# Altium schematic sheet 01mcu.SchDoc
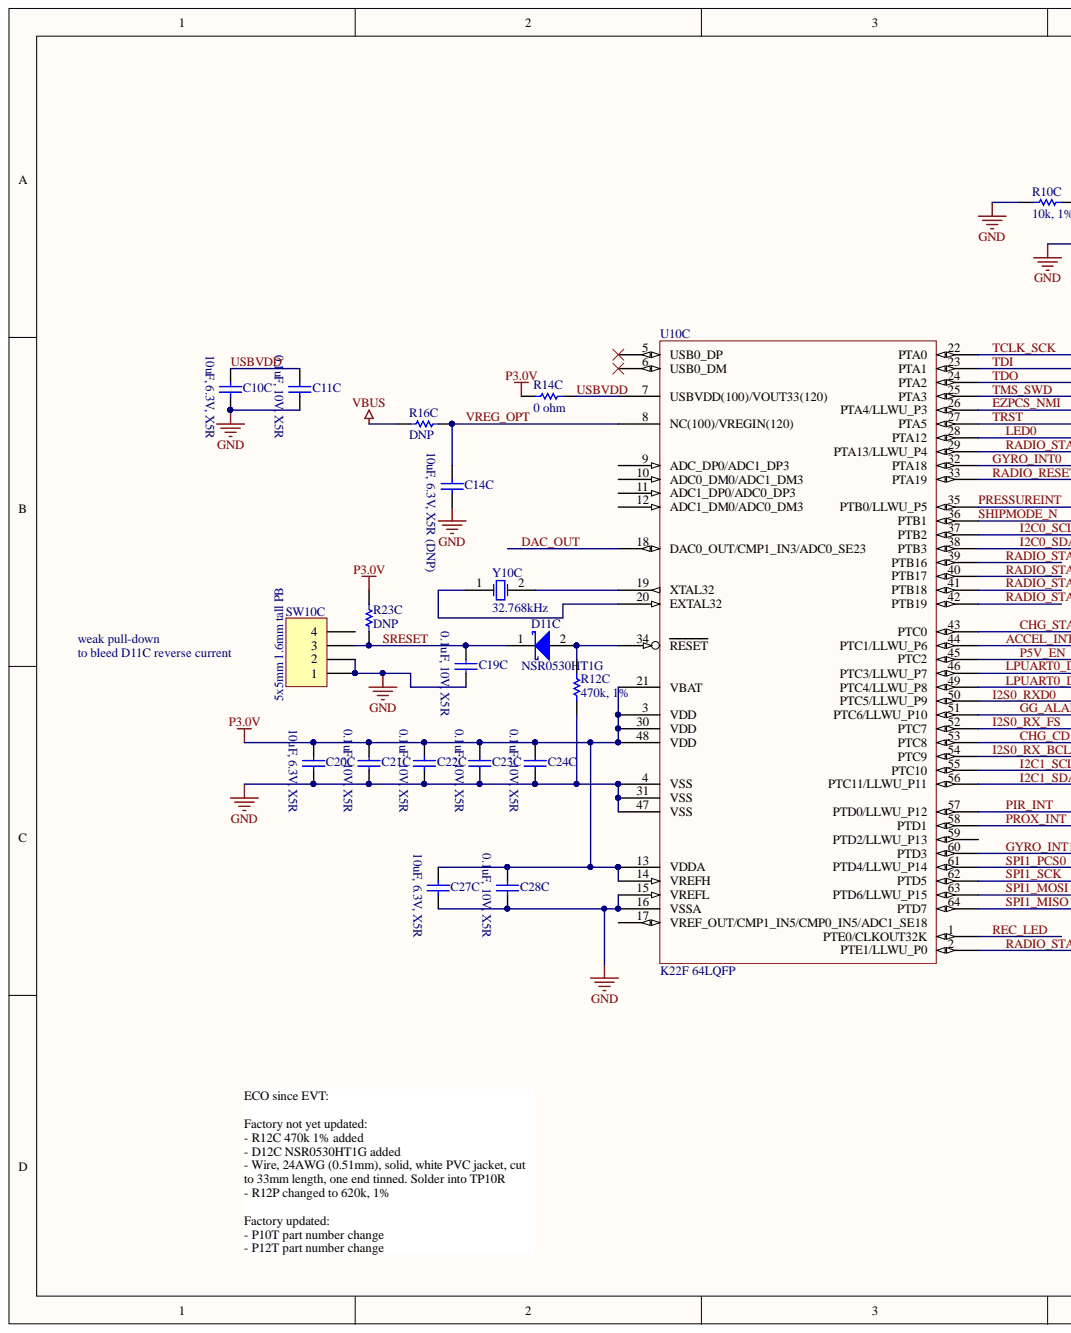
[diagram p0: 01mcu.SchDoc, left]
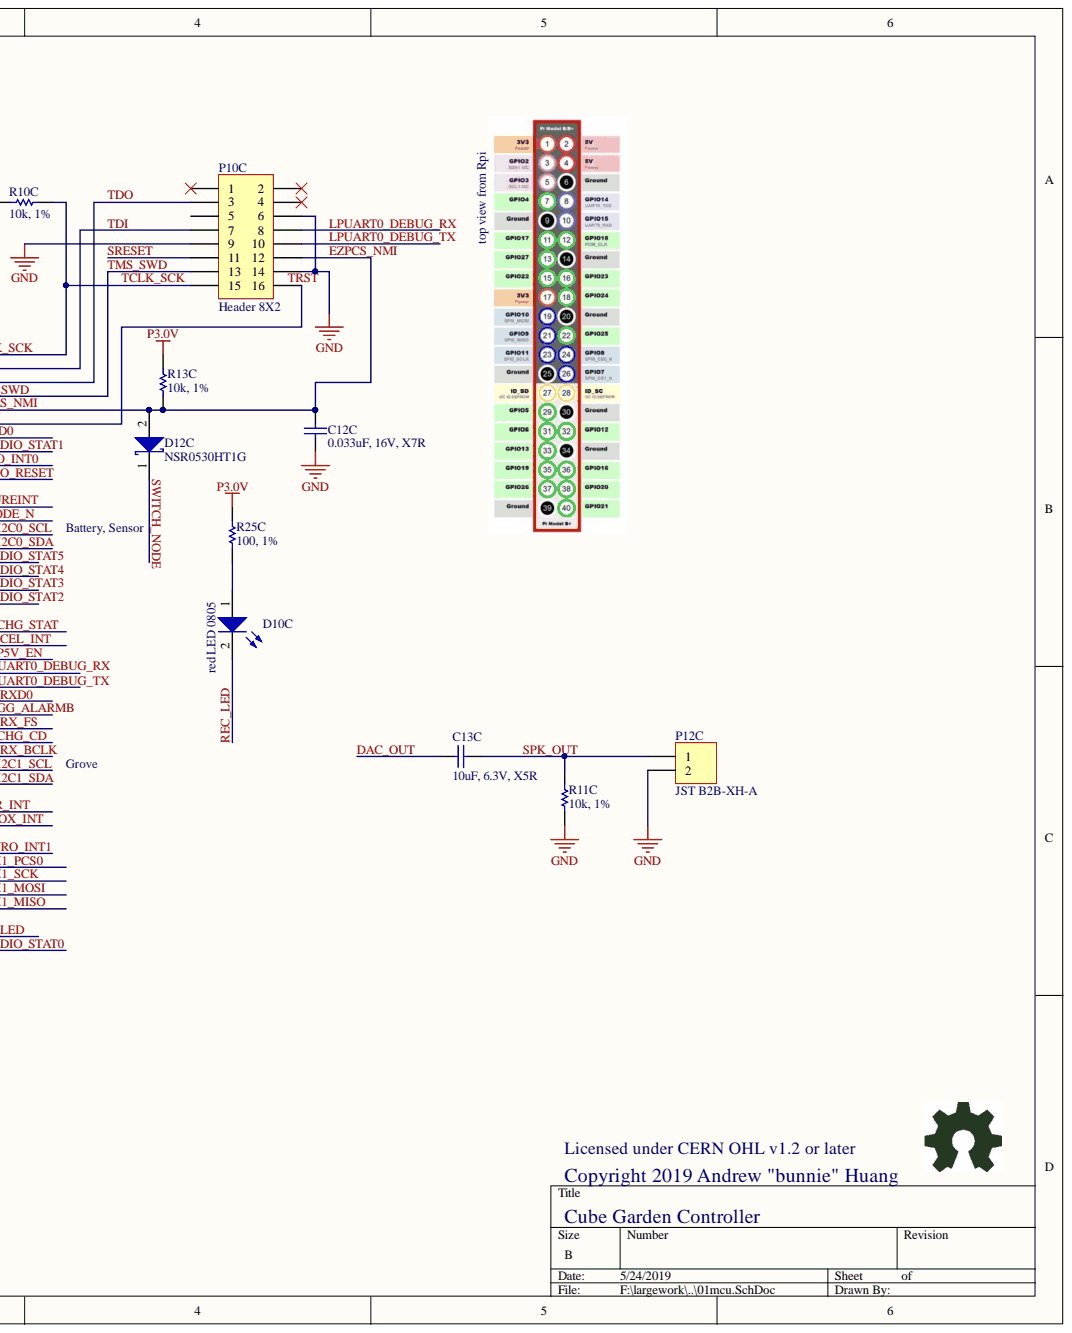
[diagram p1: 01mcu.SchDoc, right]

## Parts
C10C  10uF, 6.3V, X5R  [B_CAP0603]
C11C  0.1uF, 10V, X5R  [B_CAP0402]
C12C  0.033uF, 16V, X7R  [B_RES0402]
C13C  10uF, 6.3V, X5R  [B_CAP0603]
C14C  10uF, 6.3V, X5R (DNP)  [B_CAP0603]
C19C  0.1uF, 10V, X5R  [B_CAP0402]
C20C  10uF, 6.3V, X5R  [B_CAP0603]
C21C  0.1uF, 10V, X5R  [B_CAP0402]
C22C  0.1uF, 10V, X5R  [B_CAP0402]
C23C  0.1uF, 10V, X5R  [B_CAP0402]
C24C  0.1uF, 10V, X5R  [B_CAP0402]
C27C  10uF, 6.3V, X5R  [B_CAP0603]
C28C  0.1uF, 10V, X5R  [B_CAP0402]
D10C  red LED 0805  [CD2012-0805]
D11C  NSR0530HT1G  [SOD-323]
D12C  NSR0530HT1G  [SOD-323]
P10C  Header 8X2  [SAMTEC_SSW-108-01-T-D]
P12C  JST B2B-XH-A  VENDOR: JST  [JST_B2B-XH-A]
R10C  10k, 1%  [B_RES0402]
R11C  10k, 1%  [B_RES0402]
R12C  470k, 1%  [B_RES0402]
R13C  10k, 1%  [B_RES0402]
R14C  0 ohm  [B_RES0402]
R16C  DNP  [B_RES0402]
R23C  DNP  [B_RES0402]
R25C  100, 1%  [B_RES0402]
SW10C  5x5mm 1.6mm tall PB  [SWITCH_TS-1187A]
U10C  K22F 64LQFP  [TSQFP50P1200X1200-64N]
Y10C  32.768kHz  [ABS07-120]

## Nets
GND: C10C.2 C11C.2 C12C.2 C14C.2 C19C.2 C20C.2 C21C.2 C22C.2 C23C.2 C24C.2 C27C.2 C28C.2 P10C.6 P10C.9 P10C.14 P12C.2 R10C.2 R11C.2 R12C.2 SW10C.1 SW10C.2 U10C.4(VSS) U10C.15(VREFL) U10C.16(VSSA) U10C.31(VSS) U10C.47(VSS)
P3.0V: C20C.1 C21C.1 C22C.1 C23C.1 C24C.1 C27C.1 C28C.1 R13C.1 R14C.2 R23C.1 R25C.2 U10C.3(VDD) U10C.13(VDDA) U10C.14(VREFH) U10C.21(VBAT) U10C.30(VDD) U10C.48(VDD)
VBUS: R16C.2
ACCEL_INT: U10C.44(PTC1/LLWU_P6)
CHG_CD: U10C.53(PTC8)
CHG_STAT: U10C.43(PTC0)
DAC_OUT: C13C.1 U10C.18(DAC0_OUT/CMP1_IN3/ADC0_SE23)
EZPCS_NMI: C12C.1 D12C.2 P10C.12 R13C.2 U10C.26(PTA4/LLWU_P3)
GG_ALARMB: U10C.51(PTC6/LLWU_P10)
GYRO_INT0: U10C.32(PTA18)
GYRO_INT1: U10C.60(PTD3)
I2C0_SCL: U10C.37(PTB2)
I2C0_SDA: U10C.38(PTB3)
I2C1_SCL: U10C.55(PTC10)
I2C1_SDA: U10C.56(PTC11/LLWU_P11)
I2S0_RX_BCLK: U10C.54(PTC9)
I2S0_RX_FS: U10C.52(PTC7)
I2S0_RXD0: U10C.50(PTC5/LLWU_P9)
LED0: U10C.28(PTA12)
LPUART0_DEBUG_RX: P10C.8 U10C.46(PTC3/LLWU_P7)
LPUART0_DEBUG_TX: P10C.10 U10C.49(PTC4/LLWU_P8)
P5V_EN: U10C.45(PTC2)
PIR_INT: U10C.57(PTD0/LLWU_P12)
PRESSUREINT: U10C.35(PTB0/LLWU_P5)
PROX_INT: U10C.58(PTD1)
RADIO_RESET: U10C.33(PTA19)
RADIO_STAT0: U10C.2(PTE1/LLWU_P0)
RADIO_STAT1: U10C.29(PTA13/LLWU_P4)
RADIO_STAT2: U10C.42(PTB19)
RADIO_STAT3: U10C.41(PTB18)
RADIO_STAT4: U10C.40(PTB17)
RADIO_STAT5: U10C.39(PTB16)
REC_LED: D10C.2 U10C.1(PTE0/CLKOUT32K)
SHIPMODE_N: U10C.36(PTB1)
SPI1_MISO: U10C.64(PTD7)
SPI1_MOSI: U10C.63(PTD6/LLWU_P15)
SPI1_PCS0: U10C.61(PTD4/LLWU_P14)
SPI1_SCK: U10C.62(PTD5)
SPK_OUT: C13C.2 P12C.1 R11C.1
SRESET: C19C.1 D11C.1 P10C.11 R23C.2 SW10C.3
SWITCH_NODE: D12C.1
TCLK_SCK: P10C.15 R10C.1 U10C.22(PTA0)
TDI: P10C.7 U10C.23(PTA1)
TDO: P10C.3 U10C.24(PTA2)
TMS_SWD: P10C.13 U10C.25(PTA3)
TRST: P10C.16 U10C.27(PTA5)
USBVDD: C10C.1 C11C.1 R14C.1 U10C.7(USBVDD(100)/VOUT33(120))
VREG_OPT: C14C.1 R16C.1 U10C.8(NC(100)/VREGIN(120))
NetD10C_1: D10C.1 R25C.1
NetD11C_2: D11C.2 R12C.1 U10C.34(RESET)
NetU10C_19: U10C.19(XTAL32) Y10C.2
NetU10C_20: U10C.20(EXTAL32) Y10C.1

Not connected: P10C 1 2 4 5; SW10C 4; U10C 5(USB0_DP) 6(USB0_DM) 9(ADC_DP0/ADC1_DP3) 10(ADC0_DM0/ADC1_DM3) 11(ADC1_DP0/ADC0_DP3) 12(ADC1_DM0/ADC0_DM3) 17(VREF_OUT/CMP1_IN5/CMP0_IN5/ADC1_SE18) 59(PTD2/LLWU_P13)

## Library data of the parts
C10C C13C C20C C27C = Cap Semi: Capacitor (Semiconductor SIM Model); MPN: CL10A106MQ8NNNC; MYPN: C-106-6.3-X5R-0603; VENDOR: Samsung; Component Kind: Standard; Library Name: Miscellaneous Devices.IntLib; Signal Integrity: Cap Semi; Simulation: CAP
C11C C19C C21C C22C C23C C24C C28C = Cap Semi: Capacitor (Semiconductor SIM Model); MPN: CL05A104KP5NNNC; MYPN: C-104-10-X5R-0402; VENDOR: Samsung; Component Kind: Standard; Library Name: Miscellaneous Devices.IntLib; Signal Integrity: Cap Semi; Simulation: CAP
C12C = Cap Semi: Capacitor (Semiconductor SIM Model); MPN: CL05B333KO5NNNC; MYPN: C-333-16-X7R-0402; VENDOR: Samsung; Component Kind: Standard; Library Name: Miscellaneous Devices.IntLib; Signal Integrity: Cap Semi; Simulation: CAP
C14C = Cap Semi: Capacitor (Semiconductor SIM Model); MYPN: C-106-6.3-X5R-0603; Component Kind: Standard; Library Name: Miscellaneous Devices.IntLib; MPN: DNP; Signal Integrity: Cap Semi; Simulation: CAP; VENDOR: DNP
D10C = LED2: Red LED; MPN: CB-2012QRC-P8; MYPN: D-LED-RED-0805; VENDOR: Cheng Guang Xing; Component Kind: Standard; LatestRevisionDate: 17-Jul-2002; LatestRevisionNote: Re-released for DXP Platform.; Library Name: Miscellaneous Devices.IntLib; PackageReference: 3.2X1.6X1.1; PackageVersion: Feb-2002; Published: 8-Jun-2000; Simulation: LED2; Publisher: Altium Limited
D11C D12C = D Schottky: Schottky Diode; MPN: NSR0530H-D; MYPN: D-S-0p5-30-0p37-SOD323; VENDOR: Semtech HK LTD; Component Kind: Standard; Library Name: Miscellaneous Devices.IntLib; Revision: July-2002: Re-released for DXP Platform.; Signal Integrity: D Schottky; Simulation: SKYDIODE
P10C = Header 8X2: Header, 8-Pin, Dual row; MPN: PPPC082LFBN-RC; MYPN: J-PPPC082LFBN-RC; VENDOR: Sullins; Component Kind: Standard; LatestRevisionDate: 17-Jul-2002; LatestRevisionNote: Re-released for DXP Platform.; Library Name: Miscellaneous Connectors.IntLib; Signal Integrity: Connector; Publisher: Altium Limited
P12C = Header 2: Header, 2-Pin; MPN: B2B-XH-A(LF)(SN); MYPN: J-2.5MM-JST-TOP-TH; Component Kind: Standard; LatestRevisionDate: 17-Jul-2002; LatestRevisionNote: Re-released for DXP Platform.; Library Name: Miscellaneous Connectors.IntLib; Signal Integrity: Connector; Publisher: Altium Limited
R10C R13C = Res1: Resistor; MPN: RS02U103FT; MYPN: R-103-1P-1_16W-0402; VENDOR: Fenghua; Component Kind: Standard; Library Name: Miscellaneous Devices.IntLib; Signal Integrity: Res; Simulation: RESISTOR
R11C = Res1: Resistor; MPN: ANY; MYPN: R-103-1P-1_16W-0402; Component Kind: Standard; Library Name: Miscellaneous Devices.IntLib; Signal Integrity: Res; Simulation: RESISTOR; VENDOR: ANY
R12C = Res1: Resistor; MPN: ANY; MYPN: R-474-1P-1_16W-0402; Component Kind: Standard; Library Name: Miscellaneous Devices.IntLib; Signal Integrity: Res; Simulation: RESISTOR; VENDOR: ANY
R14C = Res1: Resistor; MPN: ANY; MYPN: R-0R0-1P-1_16W-0402; Component Kind: Standard; Library Name: Miscellaneous Devices.IntLib; Signal Integrity: Res; Simulation: RESISTOR; VENDOR: ANY
R16C = Res1: Resistor; MPN: ANY; Component Kind: Standard; Library Name: Miscellaneous Devices.IntLib; MYPN: DNP; Signal Integrity: Res; Simulation: RESISTOR; VENDOR: ANY
R23C = Res1: Resistor; VENDOR: Fenghua; Component Kind: Standard; Library Name: Miscellaneous Devices.IntLib; MPN: DNP; MYPN: DNP; Signal Integrity: Res; Simulation: RESISTOR
R25C = Res1: Resistor; MPN: RS02U101FT; MYPN: R-101-1P-1_16W-0402; VENDOR: Fenghua; Component Kind: Standard; Library Name: Miscellaneous Devices.IntLib; Signal Integrity: Res; Simulation: RESISTOR
SW10C = Header 4: Chi Fung TS-1187A; MPN: SW-PB-5X5-1.6; VENDOR: Kangdeli; Component Kind: Standard; LatestRevisionDate: 17-Jul-2002; LatestRevisionNote: Re-released for DXP Platform.; Library Name: Miscellaneous Connectors.IntLib; MYPN: SW-PB-5X5-1.6; Signal Integrity: Connector; Publisher: Altium Limited
U10C = MK22-64LQFP: NXP K22F series 64LQFP device; MPN: MK22FN128VLH10; MFG: NXP/FREESCALE; MYPN: U-MK22FN128VLH10; Component Kind: Standard; Library Name: commonsch-new.SchLib
Y10C = XTAL: 3.2 x 1.5mm 32.768 kHz crystal, 6pF CL 70k ESR, 20ppm; MPN: SJFC1332K06F20; MYPN: X-32.768K-SONGJI; VENDOR: Songji; Component Kind: Standard; Library Name: Miscellaneous Devices.IntLib; Simulation: XTAL
